# Altium schematic sheet audio in.SchDoc("audio in")
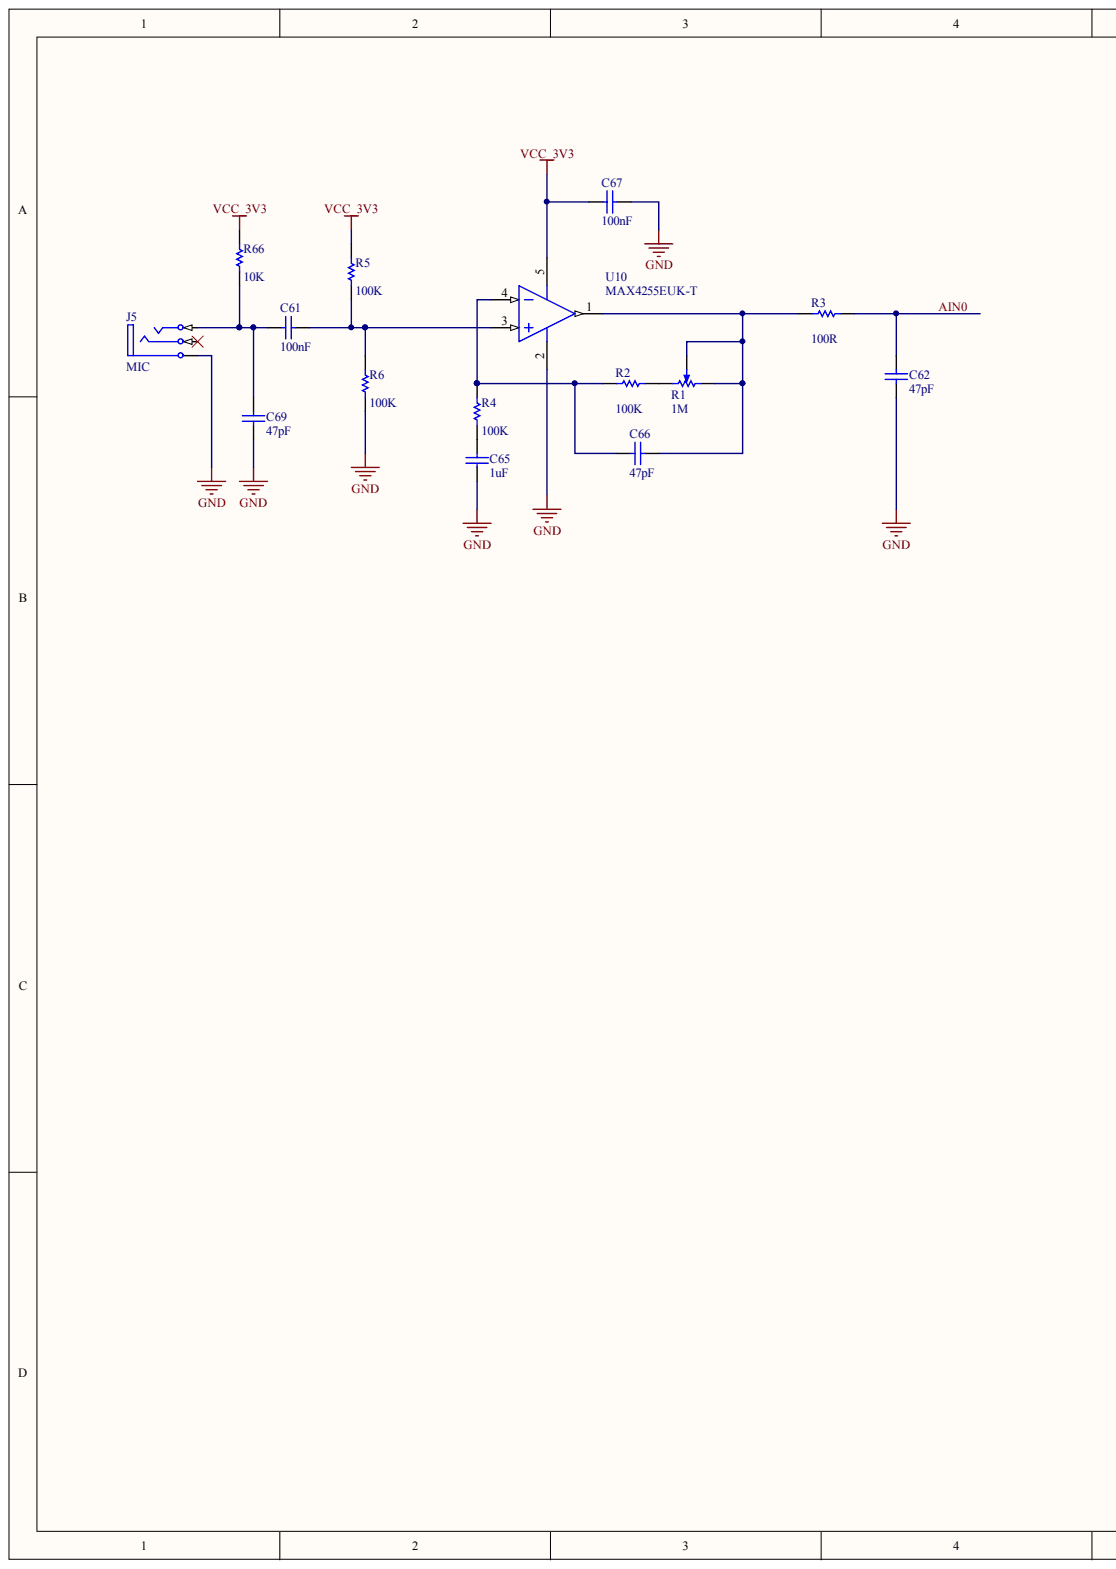
[diagram p0: audio in.SchDoc, left]
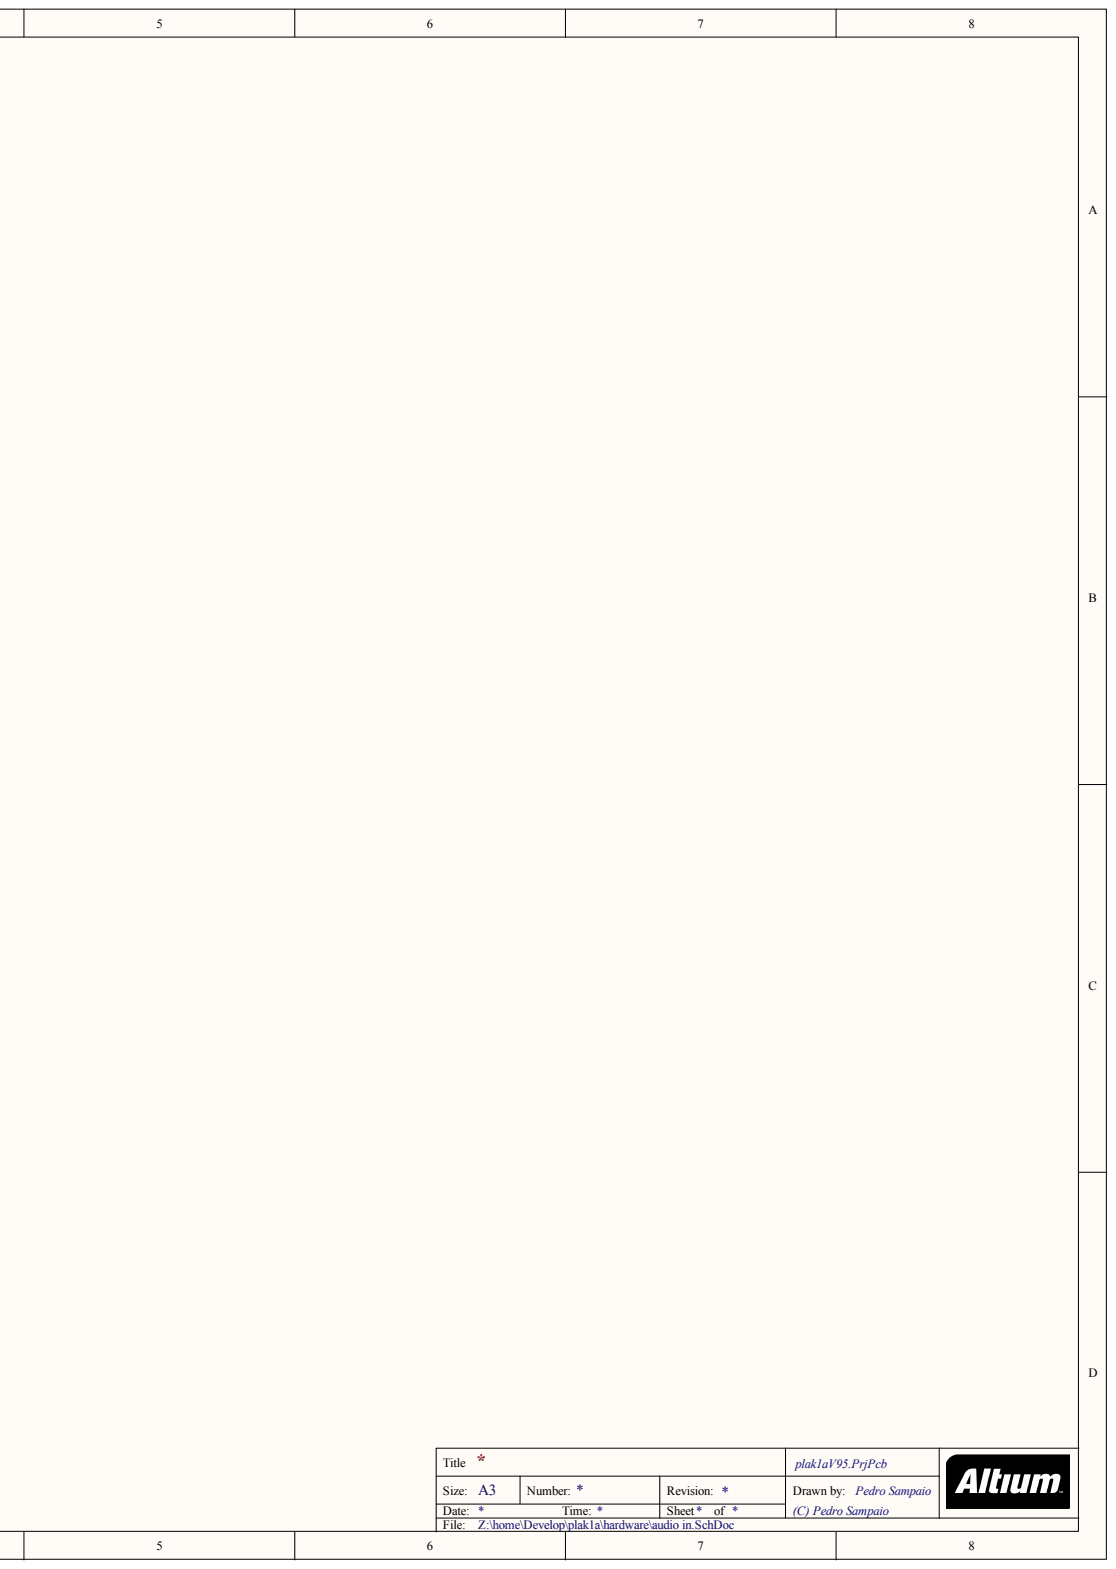
[diagram p1: audio in.SchDoc, right]

## Parts
C61  value 100nF  [0603]  (hidden comment: C0603)
C62  value 47pF  [0603]  (hidden comment: C0603)
C65  value 1uF  [2012[0805]]  (hidden comment: 8805628)
C66  value 47pF  [0603]  (hidden comment: C0603)
C67  value 100nF  [0603]  (hidden comment: C0603)
C69  value 47pF  [0603]  (hidden comment: C0603)
J5  MIC  [JACK STEREO]
R1  1M  [POT4MM-2]
R2  value 100K  [3216[1206]]  (hidden comment: Res Semi)
R3  value 100R  [3216[1206]]  (hidden comment: Res Semi)
R4  value 100K  [3216[1206]]  (hidden comment: Res Semi)
R5  value 100K  [3216[1206]]  (hidden comment: Res Semi)
R6  value 100K  [3216[1206]]  (hidden comment: Res Semi)
R66  value 10K  [3216[1206]]  (hidden comment: Res Semi)
U10  MAX4255EUK-T  [SOT23-5]

## Nets
GND: C62.1 C65.2 C67.2 C69.1 J5.1 R6.1 U10.2
VCC_3V3: C67.1 R5.2 R66.2 U10.5
AIN0: C62.2 R3.2
NetC61_1: C61.1 C69.2 J5.2 R66.1
NetC61_2: C61.2 R5.1 R6.2 U10.3
NetC65_1: C65.1 R4.1
NetC66_1: C66.1 R1.CCW R1.W R3.1 U10.1
NetC66_2: C66.2 R2.2 R4.2 U10.4
NetR1_CW: R1.CW R2.1

Not connected: J5 3

## Library data of the parts
C61 C62 C66 C67 C69 = C0603: Ceramic Chip Capacitor - Standard; Note: Footprint corresponds to dimensions for bulk cassette packaging. This case size has tightened tolerances.; Code_IPC: 1608 [0603]; Code_JEITA: 0603; Component Kind: Standard; ComponentLink1Description: Manufacturer Link; ComponentLink1URL: http://www.kemet.com/; ComponentLink2Description: Datasheet; ComponentLink2URL: http://www.kemet.com/kemet/web/homepage/kechome.nsf/vapubfilesname/F3102TA.pdf/$file/F3102TA.pdf; DatasheetDocument: Mar-2001; LatestRevisionDate: 19-Mar-2004; LatestRevisionNote: Silkscreen size reduced.; Library Name: KEMET Chip Capacitor.IntLib; PackageDocument: Mar-2001; PackageReference: 0603; Published: 17-Jul-2002; Signal Integrity: C0603; Simulation: CAP; Publisher: Altium Limited
  C61 C67: Farnell: 1301716
  C62 C66 C69: Farnell: 9406263
C65 = C0805: Ceramic Chip Capacitor - Standard; Note: Footprint corresponds to dimensions for bulk cassette packaging. This case size has tightened tolerances.; Code_IPC: 2012[0805]; Code_JEITA: 0805; Component Kind: Standard; ComponentLink1Description: Manufacturer Link; ComponentLink1URL: http://www.kemet.com/; ComponentLink2Description: Datasheet; ComponentLink2URL: http://www.kemet.com/kemet/web/homepage/kechome.nsf/vapubfilesname/F3102TA.pdf/$file/F3102TA.pdf; DatasheetDocument: Mar-2001; Farnell: 8805628; LatestRevisionDate: 19-Mar-2004; LatestRevisionNote: Silkscreen size reduced.; Library Name: KEMET Chip Capacitor.IntLib; PackageDocument: Mar-2001; PackageReference: 0805; Published: 17-Jul-2002; Signal Integrity: C0805; Simulation: CAP; Publisher: Altium Limited
J5 = Jack 3 Power: Jack Socket, 1/4" [6.5mm], Thru-Hole, Vertical, 3-Conductor Open Circuit (Non-Normalling); DrillAndSlotSize: Corresponds to nominal value.; PackageDocument: 2003; PCB_Layout: Complies with manufacturer's recommendation.; Component Kind: Standard; ComponentLink1Description: Manufacturer Link; ComponentLink1URL: http://www.cliffuk.co.uk/; DatasheetDocument: 2003; Farnell: 1243244; LatestRevisionDate: 15-Jul-2004; LatestRevisionNote: Component Replaced.; Library Name: Componentes.IntLib; PackageReference: S1VS_SSS; Published: 15-Jul-2004; Signal Integrity: JACK Socket; Publisher: Altium Limited
R1 = RPot SM: Square Trimming Potentiometer; Component Kind: Standard; ComponentLink1Description: Manufacturer Link; ComponentLink1URL: http://bourns.com/2/search.html; DatasheetDocument: Oct-2003; Library Name: Miscellaneous Devices.IntLib; PackageReference: POT4MM-2; Published: 17-Dec-2003; Value: 1M; Publisher: Altium Limited
R2-R6 R66 = Res Semi: Semiconductor Resistor; Component Kind: Standard; LatestRevisionDate: 17-Jul-2002; LatestRevisionNote: Re-released for DXP Platform.; Library Name: Miscellaneous Devices.IntLib; PackageReference: AXIAL-0.5; Published: 8-Jun-2000; Signal Integrity: Res Semi; Simulation: RES; Publisher: Altium Limited
  R2 R4-R6: Farnell: 9240764
  R3: Farnell: 1469977
  R66: Farnell: 9240640
U10 = MAX4255EUK-T: SOT23, Single-Supply, Low-Noise, Low-Distortion, Rail-to-Rail Op Amp; Component Kind: Standard; ComponentLink1Description: Manufacturer Link; ComponentLink1URL: http://www.maxim-ic.com/; ComponentLink2Description: Datasheet; ComponentLink2URL: http://pdfserv.maxim-ic.com/en/ds/MAX4249-MAX4257.pdf; DatasheetVersion: Rev 2; 4/98; Farnell: 9724001; Library Name: Maxim Operational Amplifier.IntLib; PackageDescription: SOT-23/SC-74A; 5 Leads; Body 3 x 3 mm, inc. leads (LxW max); PackageReference: SOT23-5; Published: 23-Sep-2002; Simulation: MAX4255; Publisher: Altium Limited
